# Altium schematic sheet B230.SchDoc(B230)
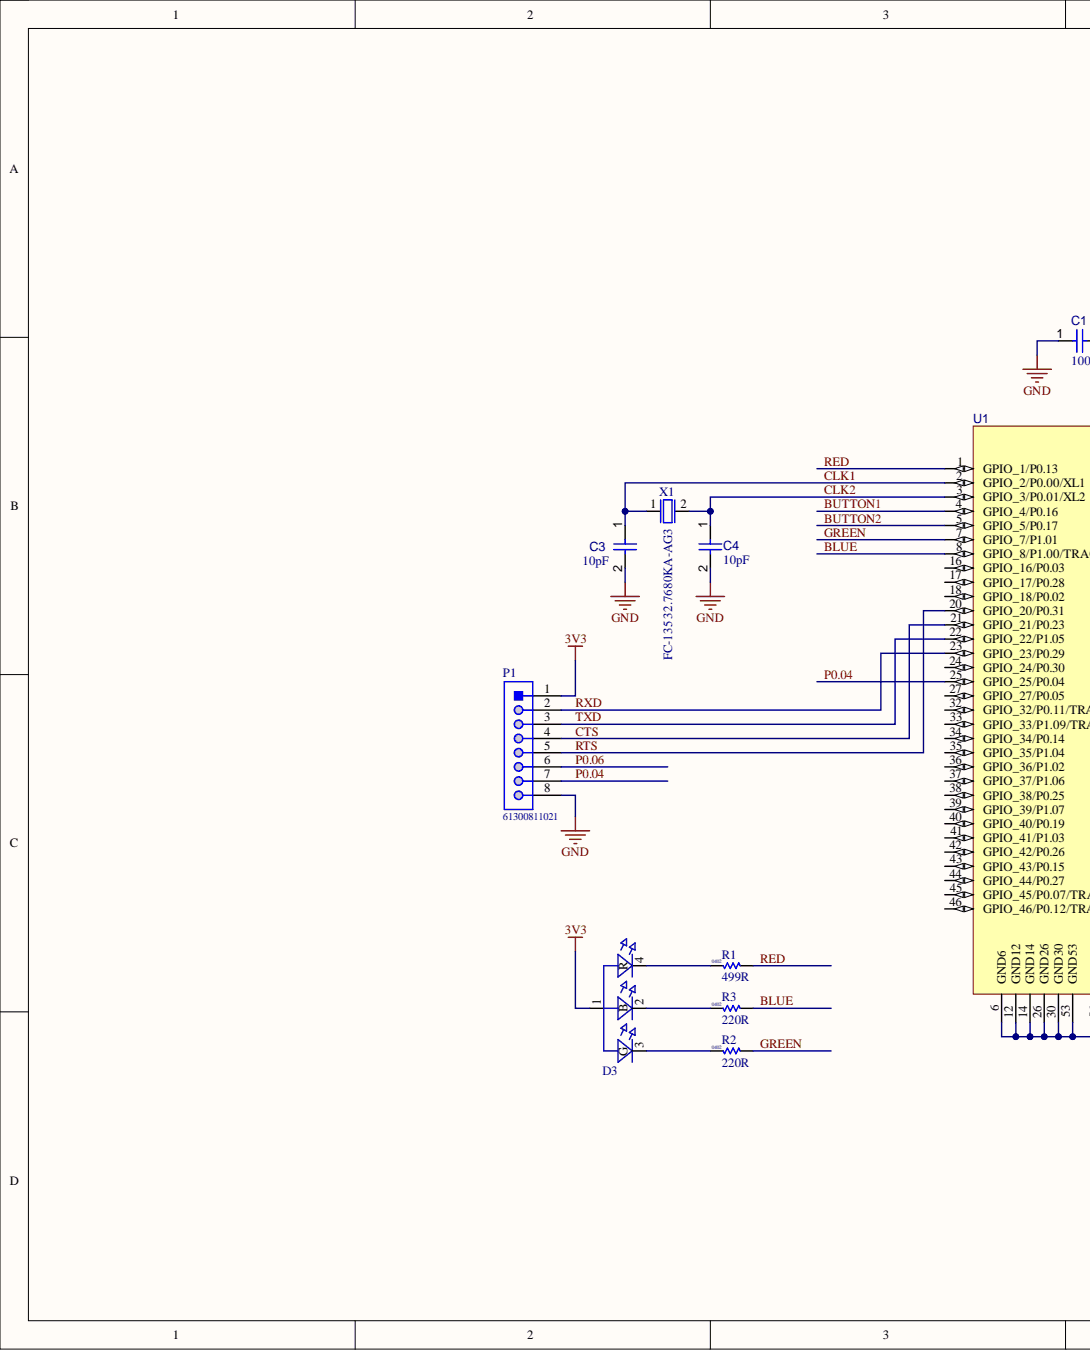
[diagram p0: B230.SchDoc, left]
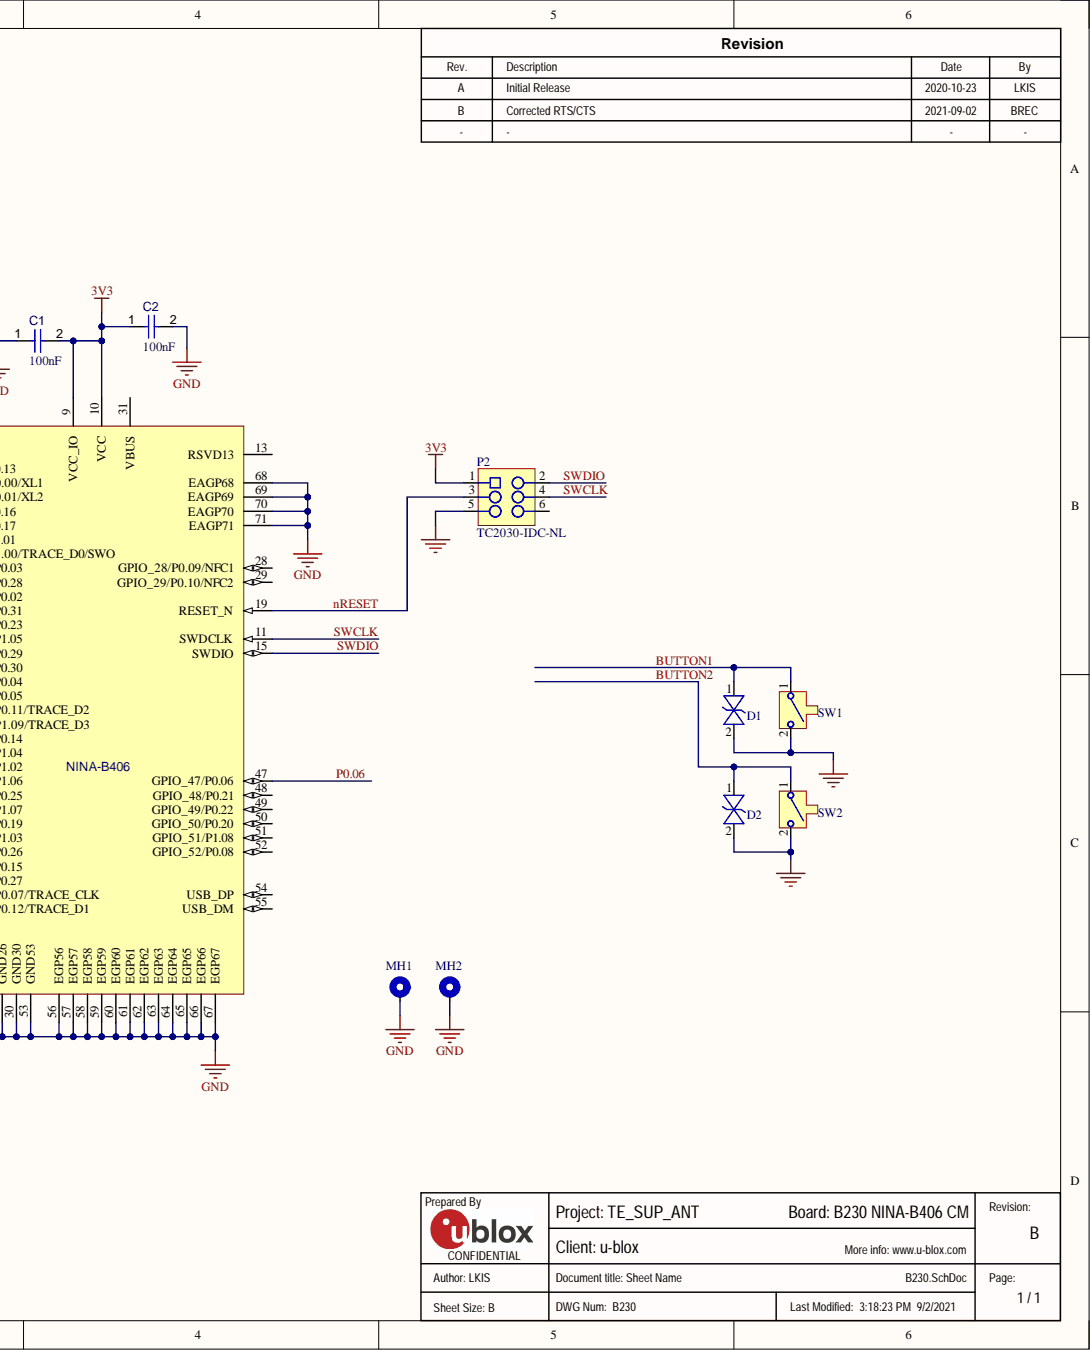
[diagram p1: B230.SchDoc, right]

## Parts
C1  value 100nF  [CAPC1005X56X25ML10T15]  (hidden comment: Capacitor 0.1 uF +/- 5% 16 V 0402)
C2  value 100nF  [CAPC1005X56X25ML10T15]  (hidden comment: Capacitor 0.1 uF +/- 5% 16 V 0402)
C3  value 10pF  [CAPC1005X55X25ML05T05]  (hidden comment: Capacitor 10 pF +/- 5% 50 V 0402)
C4  value 10pF  [CAPC1005X55X25ML05T05]  (hidden comment: Capacitor 10 pF +/- 5% 50 V 0402)
D1  110-00019  [SOD-923]  (value not drawn)
D2  110-00019  [SOD-923]  (value not drawn)
D3  115-00011  [4-PLCC]  (value not drawn)
MH1  MH_M1.5DNP  [MH_M1.5]  (value not drawn)
MH2  MH_M1.5DNP  [MH_M1.5]  (value not drawn)
P1  61300811021  [61300811021]
P2  TC2030-IDC-NLDNP  [TC2030-IDC-NL]  (value not drawn)
R1  value 499R  Size: 0402  [RES 0402 HD]  (hidden comment: 180-00031499R)
R2  value 220R  Size: 0402  [RES 0402 HD]  (hidden comment: 180-00098220R)
R3  value 220R  Size: 0402  [RES 0402 HD]  (hidden comment: 180-00098220R)
SW1  135-00007  [B3U-1000P]  (value not drawn)
SW2  135-00007  [B3U-1000P]  (value not drawn)
U1  NINA-B406  [NINA_LGA71R_1500X1000X223_PCB]
X1  100-00005  [XTAL_3215]  (value not drawn)

## Nets
3V3: C1.2 C2.1 D3.1 P1.1 P2.1 U1.9(VCC_IO) U1.10(VCC)
GND: C1.1 C2.2 C3.2 C4.2 D1.2 D2.2 MH1.1 MH2.1 P1.8 P2.5 SW1.2 SW2.2 U1.6(GND6) U1.12(GND12) U1.14(GND14) U1.26(GND26) U1.30(GND30) U1.53(GND53) U1.56(EGP56) U1.57(EGP57) U1.58(EGP58) U1.59(EGP59) U1.60(EGP60) U1.61(EGP61) U1.62(EGP62) U1.63(EGP63) U1.64(EGP64) U1.65(EGP65) U1.66(EGP66) U1.67(EGP67) U1.68(EAGP68) U1.69(EAGP69) U1.70(EAGP70) U1.71(EAGP71)
BLUE: R3.1 U1.8(GPIO_8/P1.00/TRACE_D0/SWO)
BUTTON1: D1.1 SW1.1 U1.4(GPIO_4/P0.16)
BUTTON2: D2.1 SW2.1 U1.5(GPIO_5/P0.17)
CLK1: C3.1 U1.2(GPIO_2/P0.00/XL1) X1.1
CLK2: C4.1 U1.3(GPIO_3/P0.01/XL2) X1.2
CTS: P1.4 U1.21(GPIO_21/P0.23)
GREEN: R2.1 U1.7(GPIO_7/P1.01)
nRESET: P2.3 U1.19(RESET_N)
P0.04: P1.7 U1.25(GPIO_25/P0.04)
P0.06: P1.6 U1.47(GPIO_47/P0.06)
RED: R1.1 U1.1(GPIO_1/P0.13)
RTS: P1.5 U1.20(GPIO_20/P0.31)
RXD: P1.2 U1.23(GPIO_23/P0.29)
SWCLK: P2.4 U1.11(SWDCLK)
SWDIO: P2.2 U1.15(SWDIO)
TXD: P1.3 U1.22(GPIO_22/P1.05)
NetD3_2: D3.2(B) R3.2
NetD3_3: D3.3(G) R2.2
NetD3_4: D3.4(R) R1.2

Not connected: P2 6; U1 13(RSVD13) 16(GPIO_16/P0.03) 17(GPIO_17/P0.28) 18(GPIO_18/P0.02) 24(GPIO_24/P0.30) 27(GPIO_27/P0.05) 28(GPIO_28/P0.09/NFC1) 29(GPIO_29/P0.10/NFC2) 31(VBUS) 32(GPIO_32/P0.11/TRACE_D2) 33(GPIO_33/P1.09/TRACE_D3) 34(GPIO_34/P0.14) 35(GPIO_35/P1.04) 36(GPIO_36/P1.02) 37(GPIO_37/P1.06) 38(GPIO_38/P0.25) 39(GPIO_39/P1.07) 40(GPIO_40/P0.19) 41(GPIO_41/P1.03) 42(GPIO_42/P0.26) 43(GPIO_43/P0.15) 44(GPIO_44/P0.27) 45(GPIO_45/P0.07/TRACE_CLK) 46(GPIO_46/P0.12/TRACE_D1) 48(GPIO_48/P0.21) 49(GPIO_49/P0.22) 50(GPIO_50/P0.20) 51(GPIO_51/P1.08) 52(GPIO_52/P0.08) 54(USB_DP) 55(USB_DM)

## Library data of the parts
C1 C2 = CMP-001-00001-4: Chip Capacitor, 0.1 uF, +/- 5%, 16 V, 0402 (1005 Metric); Capacitor Type: Ceramic; Voltage Rating: 16V; Case/Package: 0402; Component Kind: Standard; Max Operating Temperature: 125°C; Min Operating Temperature: -55°C; Mounting Technology: SM; Pins: 2; RoHS Compliant: True; Tolerance: 5%; Library Name: U-blox Sweden
C3 C4 = CMP-001-00013-4: Chip Capacitor, 10 pF, +/- 5%, 50 V, 0402 (1005 Metric); Capacitor Type: Ceramic; Voltage Rating: 50V; Case/Package: 0402; Component Kind: Standard; Max Operating Temperature: 125°C; Min Operating Temperature: -55°C; Mounting Technology: SM; Pins: 2; RoHS Compliant: True; Tolerance: 5%; Library Name: U-blox Sweden
D1 D2 = 110-00019: Diode, ESD9B, 3.3V, ST5G, SOD-923; Manufacturer: ON Semiconductor; Manufacturer P/N: ESD9B3.3ST5G; Part Name: Diode TVS 3.3V SOD-923; Body Size: 0.8mm x 0.6mm; Component Mounting Type: SMT; Diode Type: TVS; Item_Phase: Production; Use in new designs: Yes; Arena Link: https://app.bom.com/a/4M5DJQ9Q9SR7DV4H?v=spec.summary; Component Kind: Standard; Database Table Name: Diode; Height: 0.4mm; MFG Package: SOD-923; Package Type: SOD; Part Number: 110-00019; Part_Type: Diode; Pins: 2; Revision: 0A; Supplier 1: Arrow; Supplier Part Number 1: ESD9B3.3ST5G; Voltage: 3.3V; Library Name: Arena_Lib.DbLib
D3 = 115-00011: LED, RGB Diffused SMT, PLCC4 4 Pin; Manufacturer: Cree, Inc.; Manufacturer P/N: CLMVB-FKA-CFHEHLCBB7a363; Part Name: LED RGB SMT 2mm x 2mm; Body Size: 2.0mm x 2.0mm; Current: 20mA Red, 10mA Green, 10mA, Blue; Item_Phase: Production; Number of Circuits: 3; Use in new designs: Yes; Vf: 2V Red, 3V Green, 3V Blue; Voltage: 5V; Arena Link: https://app.bom.com/a/UCV39GZGZIHY581D?v=spec.summary; Color: RGB; Component Kind: Standard; Component Mounting Type: SMT; Database Table Name: LED; Height: 1mm; MFG Package: PLCC4; Mounting Type: Vertical; Package Type: Custom; Part Number: 115-00011; Part_Type: LED; Pins: 4; Revision: 0A; Supplier 1: Mouser; Supplier Part Number 1: 941-CLMVBFKACFHEHLCB; Library Name: Arena_Lib.DbLib
MH1 MH2 = MH_M1.5: 1.5M screw mounting hole, plated; Added By: Jeremy D; Database Table Name: Mechanical; Added Date: 7/9/2015; Component Kind: Standard; DNP: DNP; Height: 0mm; Part Number: MH_M1.5; Size: 3.4mm x 3.4mm; Type: Mounting Hole; Library Name: LEGACY_Rigado_AltiumLib.DbLib
P1 = CMP-1502-01075-1: THT Angled Pin Header WR-PHD, Pitch 2.54 mm, Single Row, 8 pins; Manufacturer: Wurth Elektronik; Contact Resistance: 20mOhm; Gender: Male; Pin Number: 8; Pitch: 2.54mm; Rated Current: 3A; Withstanding Voltage: 500VAC; Working Voltage: 250VAC; Component Kind: Standard; Datasheet URL: https://katalog.we-online.de/em/datasheet/6130xx11021.pdf; Library Name: 8-pin-ra-100mil.IntLib; Manufacturer Part Number: 61300811021; Manufacturer URL: http://www.we-online.de; Mounting Technology: THT; Operating Temperature: -40to105degC; Orientation: Angled; Package Reference: 61300811021; Packaging: Bag; Part Number: 61300811021; Row Number: Single; Publisher: WurthElektronik
P2 = TC2030-IDC-NL: CON PADS FOR CBL PLUG-OF-NAILS 6-PIN NO LEGS; Manufacturer: TAG Connect; Added By: Paul B; Database Table Name: Testpoints & Pads; Added Date: 4/22/2015; Component Kind: Standard; DNP: DNP; Height: 0; Manufacturer P/N: TC2030-IDC-NL; Part Number: TC2030-IDC-NL; Size: 6.731mm x 12.446mm; Library Name: LEGACY_Rigado_AltiumLib.DbLib
R1 = 180-00031: Resistor, 499ohm, 1%, 1/16W, 0402; Manufacturer: Rohm Semiconductor; Manufacturer P/N: MCR01MRTF4990; Part Name: RES SMD 499 OHM 1% 1/16W 0402; Component Mounting Type: SMT; Item_Phase: Production; Tolerance: +/- 1%; Use in new designs: Yes; Alternate Footprint Ref: RES 0402; Arena Link: https://app.bom.com/a/Q8RZ5CVCVEDU1SS5?v=spec.summary; Component Kind: Standard; Database Table Name: Resistor; Height: 0.4mm; Manufacturer 2: Samsung Electro-Mechanics America, Inc; Manufacturer P/N 2: RC1005F4990CS; Part Number: 180-00031; Part_Type: Resistor; Pins: 2; Power: 1/16W; Revision: 0D; Signal Integrity: Res; Simulation: RESISTOR; Supplier 1: Digi-Key; Supplier 2: Digi-Key; Supplier Part Number 1: RHM499CDCT-ND; Supplier Part Number 2: 1276-3992-1-ND; Library Name: Arena_Lib.DbLib
R2 R3 = 180-00098: Resistor, 220ohm, 5%, 1/16W, 0402.; Manufacturer: Panasonic Electronic Components; Manufacturer P/N: ERJ-2GEJ221X; Part Name: RES SMD 220 OHM 5% 1/16W 0402; Component Mounting Type: SMT; Item_Phase: Production; Tolerance: +/- 5%; Use in new designs: Yes; Alternate Footprint Ref: RES 0402; Arena Link: https://app.bom.com/a/8Q9HNUDUDWVBJC30?v=spec.summary; Component Kind: Standard; Database Table Name: Resistor; Height: 0.4mm; Manufacturer 2: Samsung Electro-Mechanics America, Inc; Manufacturer P/N 2: RC1005J221CS; Part Number: 180-00098; Part_Type: Resistor; Pins: 2; Power: 1/16W; Revision: 0C; Signal Integrity: Res; Simulation: RESISTOR; Supplier 1: Digi-Key; Supplier 2: Digi-Key; Supplier Part Number 1: P220JCT-ND; Supplier Part Number 2: 1276-4360-1-ND; Library Name: Arena_Lib.DbLib
SW1 SW2 = 135-00007: Button, Momentary SPST-NO, 0.05A, 12V, 3mm x 2.5mm; Manufacturer: Omron Electronics Inc-EMC Div; Part Name: SWITCH TACTILE SPST-NO 0.05A 12V; Component Mounting Type: SMT; Current: 50mA; Item_Phase: Production; Switch/Button Circuit: SPST; Switch/Button Function: Off-Mom; Use in new designs: Yes; Voltage: 12VDC; Arena Link: https://app.bom.com/a/TBU28FYFYHGV5FQ0?v=spec.summary; Body Size: 3mm x 2.5mm; Component Kind: Standard; Database Table Name: Button; Height: 1.2mm; Manufacturer P/N: B3U-1000P; Part Number: 135-00007; Part_Type: Button; Pins: 2; Revision: 0A; Supplier 1: Digi-Key; Supplier Part Number 1: SW1020CT-ND; Library Name: Arena_Lib.DbLib
U1 = NINA-B406: Stand-alone Bluetooth low energy module; Manufacturer: u-blox; Component Kind: Standard; Library Name: ubloxLib.SchLib; Part Description: Stand-alone Bluetooth low energy module; Value: NINA-B406
X1 = 100-00005: XTAL, 2Pin, 32.768kHz, 7pF 20ppm; Manufacturer: Epson; Manufacturer P/N: FC-135 32.7680KA-AG3; Part Name: XTAL 32.768kHz 7pF 20ppm 3215; Body Size: 3.2mm x 1.5mm; Component Mounting Type: SMT; Database Table Name: Crystal/Oscillator; Item_Phase: Production; MFG Package: 2-SMD; Use in new designs: Yes; Arena Link: https://app.bom.com/a/L3MU07Q7Q98O5PC8?v=spec.summary; CL: 7pF; Component Kind: Standard; Frequency: 32.768kHz; Frequency Tolerance: 20ppm; Height: 0.9mm; Manufacturer 2: AVX Corporation; Manufacturer P/N 2: ST3215SB32768C0HPWBB; Part Number: 100-00005; Part_Type: Crystal/Oscillator; Pins: 2; Revision: 0C; Supplier 1: Digi-Key; Supplier 2: Digi-Key; Supplier Part Number 1: SER4086CT-ND; Supplier Part Number 2: 1253-1113-1-ND; Library Name: Arena_Lib.DbLib
